# Altium schematic sheet RPi-USB-HUB.SchDoc(RPi-USB-HUB)
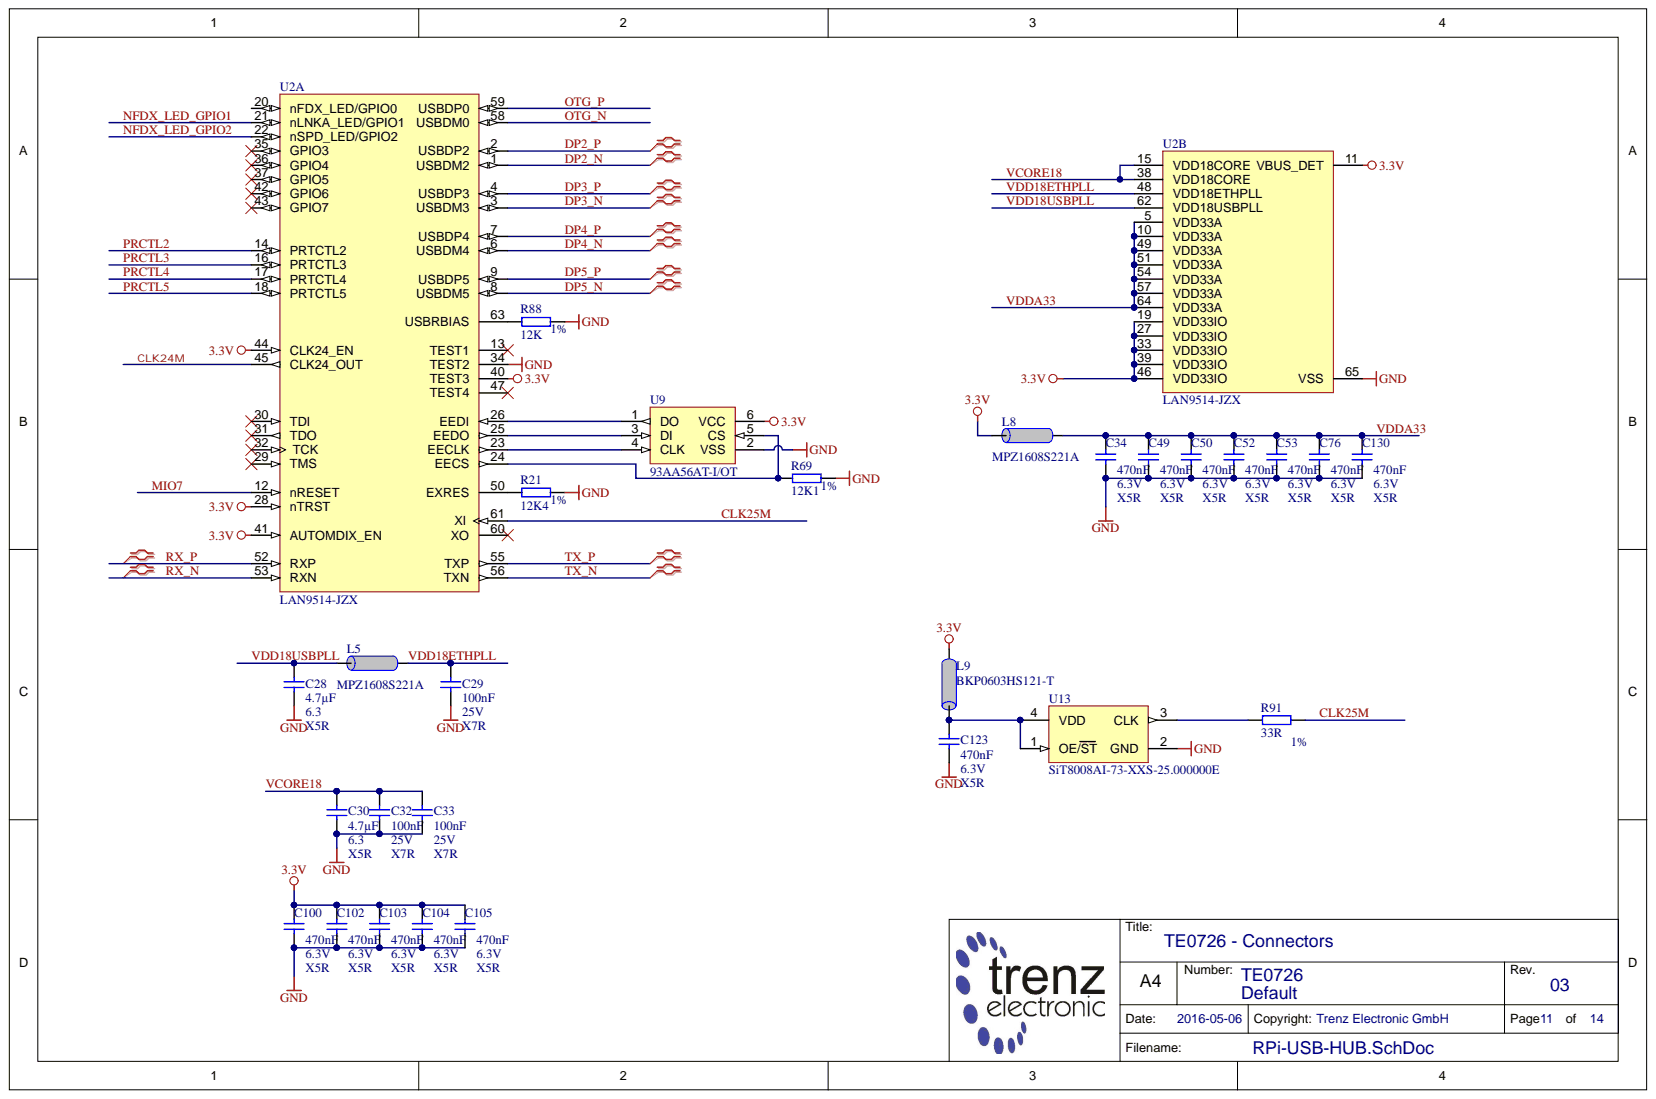
[diagram p0: RPi-USB-HUB.SchDoc]

## Parts
C28  4.7µF  Technology: X5R  Voltage: 6.3  [CAPC1005X60N]
C29  100nF  Technology: X7R  Voltage: 25V  [CAPC1005X55N]
C30  4.7µF  Technology: X5R  Voltage: 6.3  [CAPC1005X60N]
C32  100nF  Technology: X7R  Voltage: 25V  [CAPC1005X55N]
C33  100nF  Technology: X7R  Voltage: 25V  [CAPC1005X55N]
C34  470nF  Technology: X5R  Voltage: 6.3V  [CAPC0603X33N]
C49  470nF  Technology: X5R  Voltage: 6.3V  [CAPC0603X33N]
C50  470nF  Technology: X5R  Voltage: 6.3V  [CAPC0603X33N]
C52  470nF  Technology: X5R  Voltage: 6.3V  [CAPC0603X33N]
C53  470nF  Technology: X5R  Voltage: 6.3V  [CAPC0603X33N]
C76  470nF  Technology: X5R  Voltage: 6.3V  [CAPC0603X33N]
C100  470nF  Technology: X5R  Voltage: 6.3V  [CAPC0603X33N]
C102  470nF  Technology: X5R  Voltage: 6.3V  [CAPC0603X33N]
C103  470nF  Technology: X5R  Voltage: 6.3V  [CAPC0603X33N]
C104  470nF  Technology: X5R  Voltage: 6.3V  [CAPC0603X33N]
C105  470nF  Technology: X5R  Voltage: 6.3V  [CAPC0603X33N]
C123  470nF  Technology: X5R  Voltage: 6.3V  [CAPC0603X33N]
C130  470nF  Technology: X5R  Voltage: 6.3V  [CAPC0603X33N]
L5  MPZ1608S221A  [INDC1608X95N]
L8  MPZ1608S221A  [INDC1608X95N]
L9  BKP0603HS121-T  [INDC0603X33N]
R21  12K4  Tolerance: 1%  [RESC1005X40N]
R69  12K1  Tolerance: 1%  [RESC1005X40N]
R88  12K  Tolerance: 1%  [RESC1005X40N]
R91  33R  Tolerance: 1%  [RESC0603X26N]
U2  LAN9514-JZX  [QFN50P900X900X80-65N-730]  (parts A,B)
U9  93AA56AT-I/OT  [SOT95P280X145-6N]
U13  SiT8008AI-73-XXS-25.000000E  Database Table Name: CLK  [2016]

## Nets
3.3V: C100.2 C102.2 C103.2 C104.2 C105.2 L8.2 L9.1 U2.11(VBUS_DET) U2.19(VDD33IO) U2.27(VDD33IO) U2.28(nTRST) U2.33(VDD33IO) U2.39(VDD33IO) U2.40(TEST3) U2.41(AUTOMDIX_EN) U2.44(CLK24_EN) U2.46(VDD33IO) U9.6(VCC)
GND: C28.2 C29.2 C30.2 C32.2 C33.2 C34.1 C49.1 C50.1 C52.1 C53.1 C76.1 C100.1 C102.1 C103.1 C104.1 C105.1 C123.2 C130.1 R21.2 R69.2 R88.2 U2.34(TEST2) U2.65(VSS) U9.2(VSS) U13.2(GND)
CLK24M: U2.45(CLK24_OUT)
CLK25M: R91.2 U2.61(XI)
DP2_N: U2.1(USBDM2)
DP2_P: U2.2(USBDP2)
DP3_N: U2.3(USBDM3)
DP3_P: U2.4(USBDP3)
DP4_N: U2.6(USBDM4)
DP4_P: U2.7(USBDP4)
DP5_N: U2.8(USBDM5)
DP5_P: U2.9(USBDP5)
MIO7: U2.12(nRESET)
NFDX_LED_GPIO1: U2.21(nLNKA_LED/GPIO1)
NFDX_LED_GPIO2: U2.22(nSPD_LED/GPIO2)
OTG_N: U2.58(USBDM0)
OTG_P: U2.59(USBDP0)
PRCTL2: U2.14(PRTCTL2)
PRCTL3: U2.16(PRTCTL3)
PRCTL4: U2.17(PRTCTL4)
PRCTL5: U2.18(PRTCTL5)
RX_N: U2.53(RXN)
RX_P: U2.52(RXP)
TX_N: U2.56(TXN)
TX_P: U2.55(TXP)
VCORE18: C30.1 C32.1 C33.1 U2.15(VDD18CORE) U2.38(VDD18CORE)
VDD18ETHPLL: C29.1 L5.1 U2.48(VDD18ETHPLL)
VDD18USBPLL: C28.1 L5.2 U2.62(VDD18USBPLL)
VDDA33: C34.2 C49.2 C50.2 C52.2 C53.2 C76.2 C130.2 L8.1 U2.5(VDD33A) U2.10(VDD33A) U2.49(VDD33A) U2.51(VDD33A) U2.54(VDD33A) U2.57(VDD33A) U2.64(VDD33A)
NetC123_1: C123.1 L9.2 U13.1(OE/ST) U13.4(VDD)
NetR21_1: R21.1 U2.50(EXRES)
NetR69_1: R69.1 U2.24(EECS) U9.5(CS)
NetR88_1: R88.1 U2.63(USBRBIAS)
NetR91_1: R91.1 U13.3(CLK)
NetU2_23: U2.23(EECLK) U9.4(CLK)
NetU2_25: U2.25(EEDO) U9.3(DI)
NetU2_26: U2.26(EEDI) U9.1(DO)

Not connected: U2 13(TEST1) 20(nFDX_LED/GPIO0) 29(TMS) 30(TDI) 31(TDO) 32(TCK) 35(GPIO3) 36(GPIO4) 37(GPIO5) 42(GPIO6) 43(GPIO7) 47(TEST4) 60(XO)

## Library data of the parts
C28 C30 = 24719: KON 4.7µF 6.3V X5R SMD 20%; Database Table Name: C_1005_0402; Mixed: SMT; Preferred: Yes; Value: 4.7u; AN: 24719; Case: 1005 (0402); Component Kind: Standard; Library Name: TE.DbLib; Tolerance: 20%
C29 C32 C33 = 22472: KON 100nF 25V X5R SMD; Database Table Name: C_1005_0402; Mixed: SMT; Preferred: Yes; Value: 100n; AN: 22472; Case: 1005 (0402); Component Kind: Standard; Library Name: TE.DbLib
C34 C49 C50 C52 C53 C76 C100 C102-C105 C123 C130 = 24921: KON 470nF X5R 6.3V SMD 20%; Database Table Name: C_0603_0201; Mixed: SMT; Preferred: Yes; Value: 470n; AN: 24921; Case: 0603 (0201); Component Kind: Standard; Library Name: TE.DbLib; Tolerance: 20%
L5 L8 = 22718: Ferritdurchführung MPZ1608S221A; Database Table Name: L_1608_0603; Mixed: SMT; Preferred: Yes; Value: 220 OHm @ 100MHz; AN: 22718; Case: 1608 (0603); Component Kind: Standard; H-ART: MPZ1608S221A; Library Name: TE.DbLib; Trenz-Stock: Yes; HelpURL: B:\AD\adlib\datasheet\MPZ1608
L9 = 25393: Ferritdurchführung SMD 0201; Database Table Name: L_0603_0201; Mixed: SMT; Preferred: Yes; Tolerance: 25%; AN: 25393; Case: 0603 (0201); Component Kind: Standard; H-ART: BKP0603HS121-T; Library Name: TE.DbLib; Trenz-Stock: Yes; HelpURL: B:\AD\adlib\datasheet\MULTILAYER CHIP BEAD INDUCTORS BK
R21 = 27133: WID SMD 12K4 1% 0,063W; Database Table Name: R_1005_0402; Mixed: SMT; Preferred: Yes; Value: 12.4K; AN: 27133; Case: 1005 (0402); Component Kind: Standard; Library Name: TE.DbLib; Technology: TK100
R69 = 22849: WID SMD 12K1 1% 0,063W; Database Table Name: R_1005_0402; Mixed: SMT; Preferred: Yes; Value: 12.1K; AN: 22849; Case: 1005 (0402); Component Kind: Standard; Library Name: TE.DbLib; Technology: TK100
R88 = 22598: WID SMD 12K 1% 0,063W; Database Table Name: R_1005_0402; Mixed: SMT; Preferred: Yes; AN: 22598; Case: 1005 (0402); Component Kind: Standard; Library Name: TE.DbLib; Technology: TK100; Value: 12K
R91 = 25021: WID SMD 33R 1% 0,05W; Database Table Name: R_0603_0201; Mixed: SMT; Preferred: Yes; Value: 33; AN: 25021; Case: 0603 (0201); Component Kind: Standard; Library Name: TE.DbLib; Technology: TK100; HelpURL: B:\AD\adlib\datasheet\R0201
U2 = 26963: Database Table Name: IF; Mixed: SMT; Trenz-Stock: Yes; AN: 26963; Case: QFP64; Component Kind: Standard; Description: LAN9514-JZX; H-ART: LAN9514-JZX; Library Name: TE.DbLib; HelpURL: B:\ad\adlib\datasheet\LAN9514
U9 = 27258: Database Table Name: EEPROM; H-ART: 93AA56BT-I/OT; Mixed: SMT; Preferred: Yes; AN: 27258; Case: SOT23-6; Component Kind: Standard; Description: 93AA56AT-I/OT; Library Name: TE.DbLib; Trenz-Stock: Yes; HelpURL: B:\AD\adlib\datasheet\93AA56A
U13 = 25008: SiT8008AI-73-XXS-25.000000E MEMS Oszillator 25MHz; Mixed: SMT; Preferred: Yes; Value: 25M; AN: 25008; Case: 2.0  x 1.6mm; Component Kind: Standard; H-ART: SiT8008AI-73-XXS-25.000000E; Library Name: TE.DbLib; Trenz-Stock: Yes; HelpURL: B:\AD\adlib\datasheet\SiT8008
